# Altium schematic sheet Gro_Ultrasonic_Sensor.SchDoc
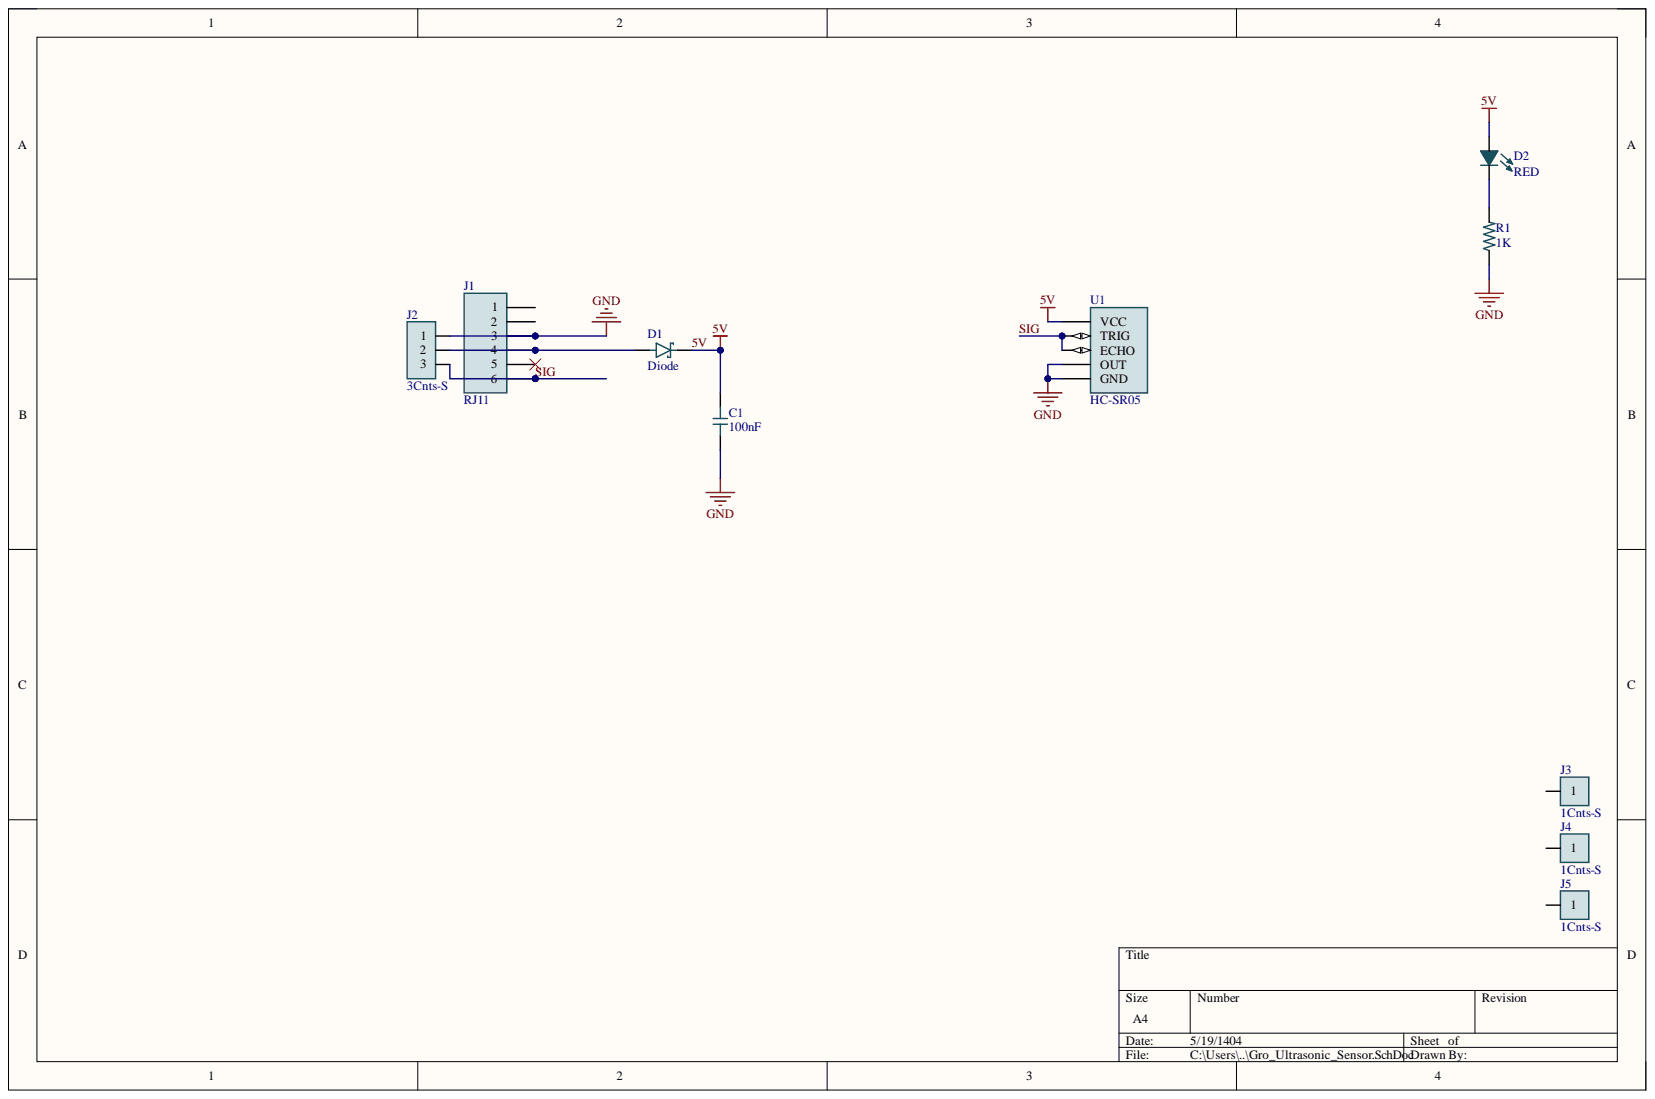
[diagram p0: Gro_Ultrasonic_Sensor.SchDoc]

## Parts
C1  100nF  [CAP1206]
D1  Diode  [SS36]
D2  RED  [LED1206]
J1  RJ11  [RJ11-6P6C]
J2  3Cnts-S  [3Cnts-S]
J3  1Cnts-S  [Hole3]
J4  1Cnts-S  [Hole3]
J5  1Cnts-S  [Hole3]
R1  1K  [RES1206]
U1  HC-SR05  [HC-SR05]

## Nets
GND: C1.2 J1.3 J2.1 R1.1 U1.4(OUT) U1.5(GND)
5V: C1.1 D1.C D2.A U1.1(VCC)
SIG: J1.6 J2.3 U1.2(TRIG) U1.3(ECHO)
NetD1_A: D1.A J1.4 J2.2
NetD2_C: D2.C R1.2

Not connected: J1 1 2 5; J3 1; J4 1; J5 1

## Library data of the parts
C1 = Capacitor: CAP SMD; MF: AVX; MP: LD031A101GAB2A; Availability: Unavailable; Component Kind: Standard; Package: 0603 AVX; Price: None; SnapEDA_Link: https://www.snapeda.com/parts/LD031A101GAB2A/AVX/view-part/1973966/?ref=snap; Library Name: Hossein72T.IntLib
D1 = Schottky Diode: MF: Diotec Semiconductor; MP: S1M; Availability: In Stock; Check_prices: https://www.snapeda.com/parts/S1M/Diotec/view-part/?ref=eda; Component Kind: Standard; Description: Schottky Diode; Package: DO-214AC-2 Diotec; Price: None; Purchase-URL: https://pricing.snapeda.com/search/part/S1M/?ref=eda; SnapEDA_Link: https://www.snapeda.com/parts/S1M/Diotec/view-part/?ref=snap; Library Name: Hossein72T.IntLib
D2 = LED: MP: LTW-C191TLA; Availability: Unavailable; Component Kind: Standard; Description: LED; Package: None; Price: None; Value: LED; Library Name: Hossein72T.IntLib
J1 = RJ11-6P6C: Jack Modular Right Angle Through Hole RJ12 6 Pos 6 Cnts Gold | Molex Incorporated 95501-2661; Check_prices: https://www.snapeda.com/parts/95501-2661/Molex/view-part/?ref=eda; Component Kind: Standard; MF: Molex; MP: 95501-2661; Package: None; SnapEDA_Link: https://www.snapeda.com/parts/95501-2661/Molex/view-part/?ref=snap; Library Name: Hossein72T.IntLib
J2 = 3Cnts-S: MP: MTSW-104-06-T-S-115; Availability: Unavailable; Component Kind: Standard; Description: 3Cnts-S; Package: None; Price: None; Library Name: Hossein72T.IntLib
J3-J5 = 1Cnts-S: MP: MTSW-101-09-G-D-235; Availability: Unavailable; Component Kind: Standard; Description: 1Cnts-S; Package: None; Price: None; Library Name: Hossein72T.IntLib
R1 = Resistor: RES 0.27 OHM 1% 1/10W; MF: TE Connectivity; MP: 3-1879460-5; Power_Rating: .1 W; Resistance: 27; Availability: In Stock; Check_prices: https://www.snapeda.com/parts/3-1879460-5/TE+Connectivity/view-part/?ref=eda; Component Kind: Standard; EU_RoHS_Compliance: Compliant with Exemptions; Package: 0603; Price: None; SnapEDA_Link: https://www.snapeda.com/parts/3-1879460-5/TE+Connectivity/view-part/?ref=snap; Tolerance: 1%; Library Name: Hossein72T.IntLib
U1 = HC-SR05: Ultrasonic Sensor Module; MP: HC-SR04; Availability: Unavailable; Component Kind: Standard; Package: None; Price: None; Library Name: Hossein72T.IntLib
